# Altium schematic sheet Sheet2.SchDoc(Sheet2)
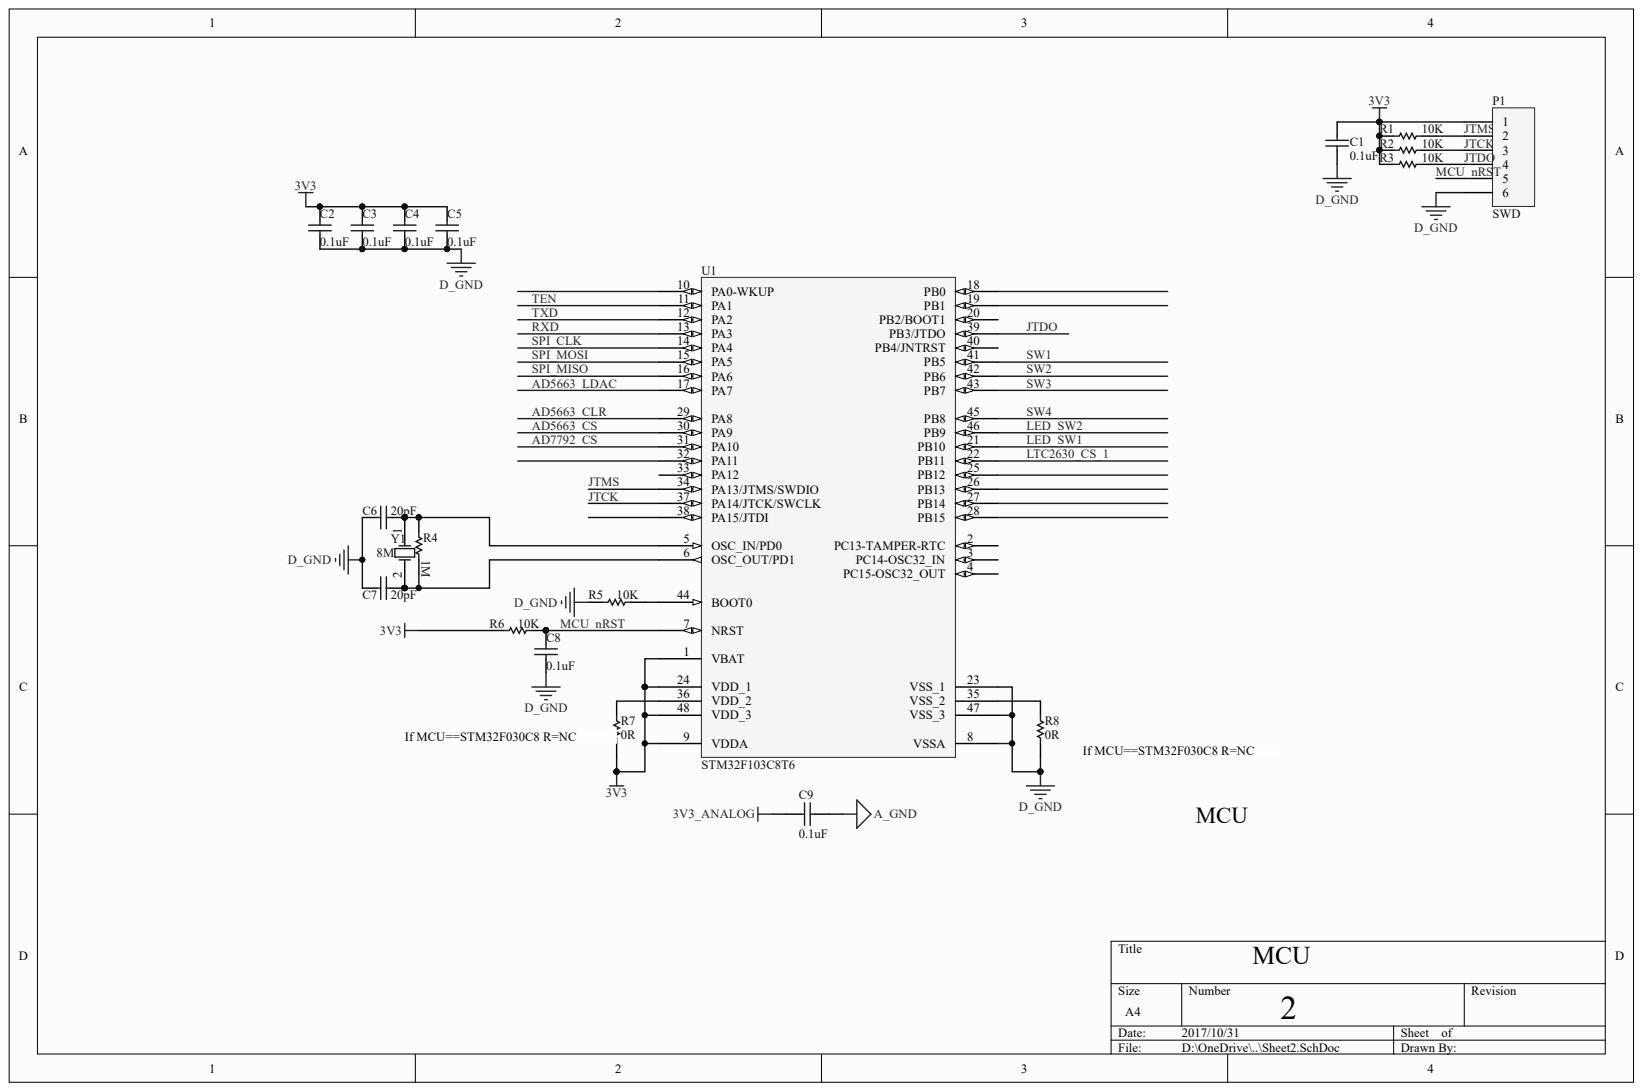
[diagram p0: Sheet2.SchDoc]

## Parts
C1  value 0.1uF  (hidden comment: Cap)
C2  value 0.1uF  (hidden comment: Cap)
C3  value 0.1uF  (hidden comment: Cap)
C4  value 0.1uF  (hidden comment: Cap)
C5  value 0.1uF  (hidden comment: Cap)
C6  value 20pF  (hidden comment: Cap)
C7  value 20pF  (hidden comment: Cap)
C8  value 0.1uF  (hidden comment: Cap)
C9  value 0.1uF  (hidden comment: Cap)
P1  SWD
R1  value 10K  (hidden comment: Res1)
R2  value 10K  (hidden comment: Res1)
R3  value 10K  (hidden comment: Res1)
R4  value 1M  (hidden comment: Res1)
R5  value 10K  (hidden comment: Res1)
R6  value 10K  (hidden comment: Res1)
R7  value 0R  (hidden comment: Res1)
R8  value 0R  (hidden comment: Res1)
U1  STM32F103C8T6
Y1  8M

## Nets
3V3: C1.2 C2.2 C3.2 C4.2 C5.2 P1.1 R1.1 R2.1 R3.1 R6.1 R7.1 U1.1(VBAT) U1.9(VDDA) U1.24(VDD_1) U1.48(VDD_3)
3V3_ANALOG: C9.1
A_GND: C9.2
D_GND: C1.1 C2.1 C3.1 C4.1 C5.1 C6.2 C7.1 C8.1 P1.6 R5.1 R8.1 U1.8(VSSA) U1.23(VSS_1) U1.47(VSS_3)
AD5663_CLR: U1.29(PA8)
AD5663_CS: U1.30(PA9)
AD5663_LDAC: U1.17(PA7)
AD7792_CS: U1.31(PA10)
JTCK: P1.3 R2.2 U1.37(PA14/JTCK/SWCLK)
JTDO: P1.4 R3.2 U1.39(PB3/JTDO)
JTMS: P1.2 R1.2 U1.34(PA13/JTMS/SWDIO)
LED_SW1: U1.21(PB10)
LED_SW2: U1.46(PB9)
LTC2630_CS_1: U1.22(PB11)
MCU_NRST: C8.2 P1.5 R6.2 U1.7(NRST)
RXD: U1.13(PA3)
SPI_CLK: U1.14(PA4)
SPI_MISO: U1.16(PA6)
SPI_MOSI: U1.15(PA5)
SW1: U1.41(PB5)
SW2: U1.42(PB6)
SW3: U1.43(PB7)
SW4: U1.45(PB8)
TEN: U1.11(PA1)
TXD: U1.12(PA2)
NetC6_1: C6.1 R4.1 U1.5(OSC_IN/PD0) Y1.1
NetC7_2: C7.2 R4.2 U1.6(OSC_OUT/PD1) Y1.2
NetR5_2: R5.2 U1.44(BOOT0)
NetR7_2: R7.2 U1.36(VDD_2)
NetR8_2: R8.2 U1.35(VSS_2)

Not connected: U1 2(PC13-TAMPER-RTC) 3(PC14-OSC32_IN) 4(PC15-OSC32_OUT) 10(PA0-WKUP) 18(PB0) 19(PB1) 20(PB2/BOOT1) 25(PB12) 26(PB13) 27(PB14) 28(PB15) 32(PA11) 33(PA12) 38(PA15/JTDI) 40(PB4/JNTRST)

## Library data of the parts
C1-C9 P1 R1-R8 U1 Y1 = (no symbol name): Publisher: Altium Limited
  C1: Published: 8-Jun-2000; LatestRevisionDate: 17-Jul-2002; LatestRevisionNote: Re-released for DXP Platform.; PackageReference: 0603
  C2-C9: Published: 8-Jun-2000; LatestRevisionDate: 17-Jul-2002; LatestRevisionNote: Re-released for DXP Platform.; PackageReference: RAD-0.3
  P1: LatestRevisionDate: 17-Jul-2002; LatestRevisionNote: Re-released for DXP Platform.
  R1-R8: Published: 8-Jun-2000; LatestRevisionDate: 17-Jul-2002; LatestRevisionNote: Re-released for DXP Platform.; PackageReference: AXIAL-0.3
  U1: Published: 30-May-2008; DatasheetVersion: rev6, Mar-2008; PackageVersion: Mar-2008; PackageReference: LQFP48; PackageDescription: 48-Pin Low-Profile Quad Flat Pack, 7 x 7 mm Body, 0.5 mm Pitch (LQFP48); ComponentLink1Description: Manufacturer Link; ComponentLink1URL: www.st.com/stm32; ComponentLink2Description: Datasheet; ComponentLink2URL: http://www.st.com/stonline/products/literature/ds/13587.pdf
  Y1: Published: 8-Jun-2000; LatestRevisionDate: 17-Jul-2002; LatestRevisionNote: Re-released for DXP Platform.; PackageReference: R38
